# Altium schematic sheet 01_ATmega2560_MCU.SchDoc
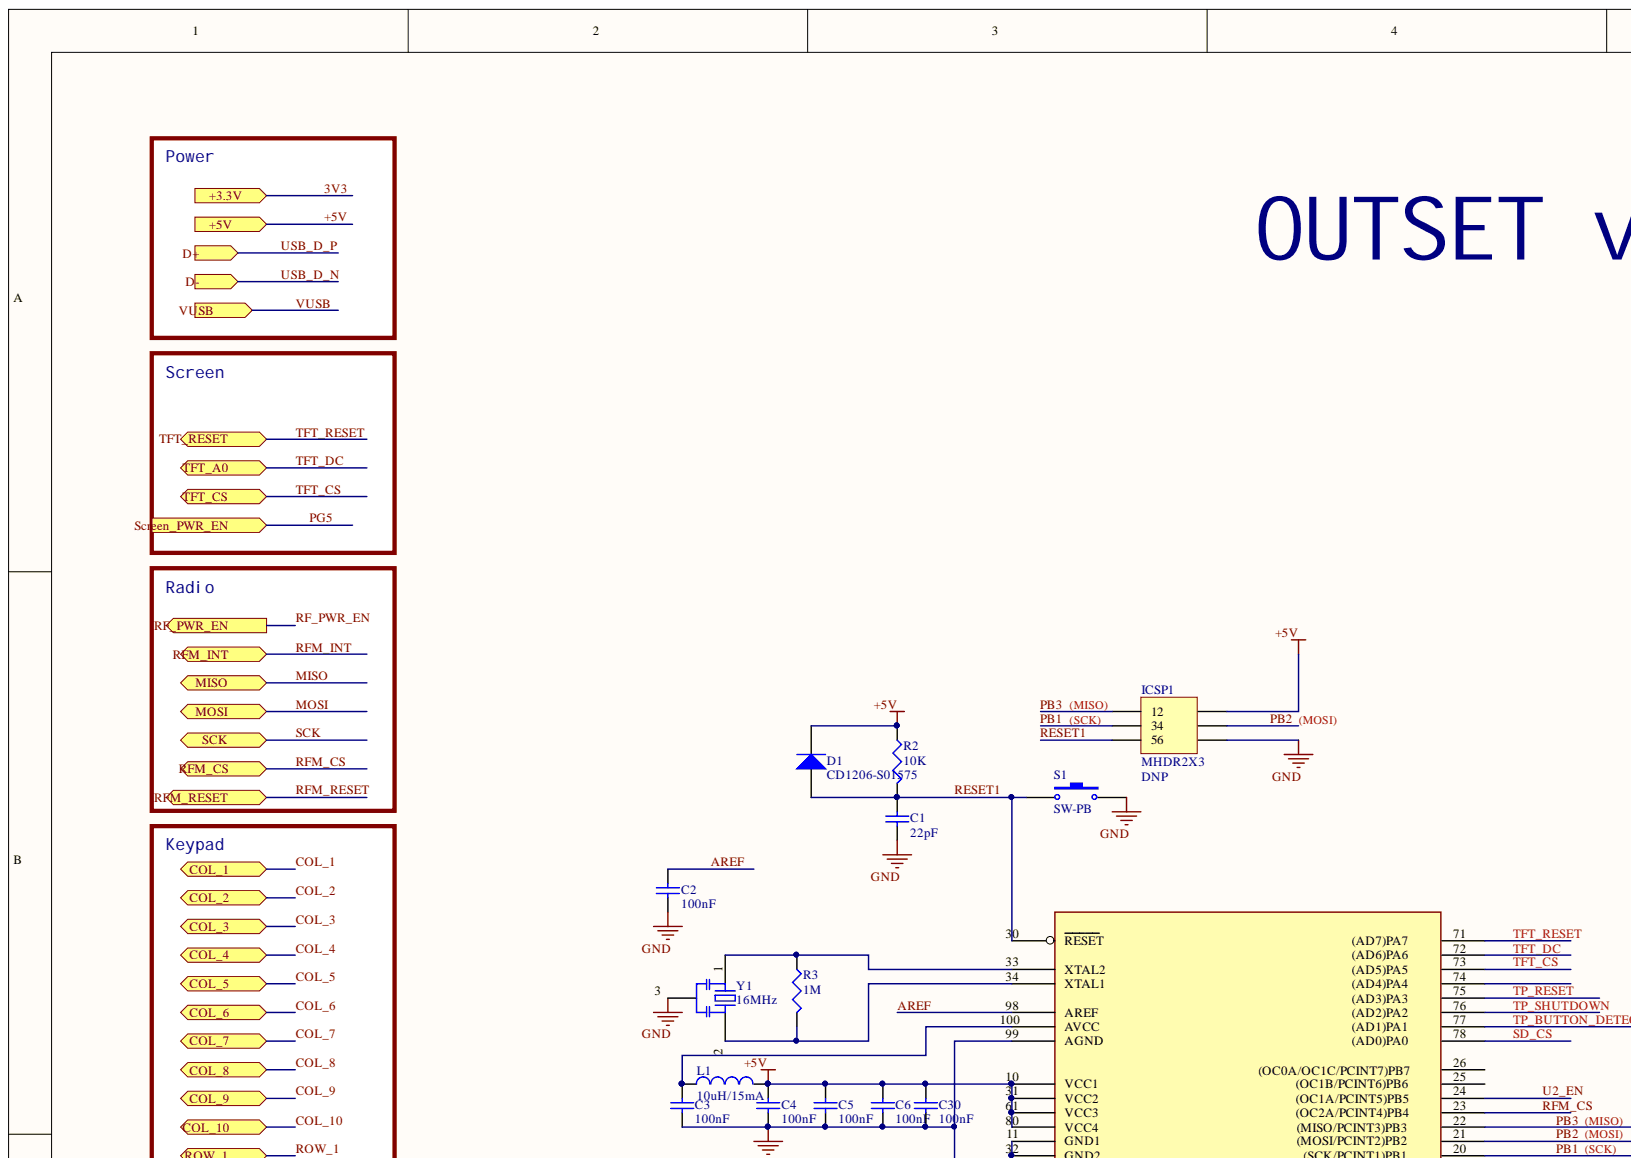
[diagram p0: 01_ATmega2560_MCU.SchDoc, top left]
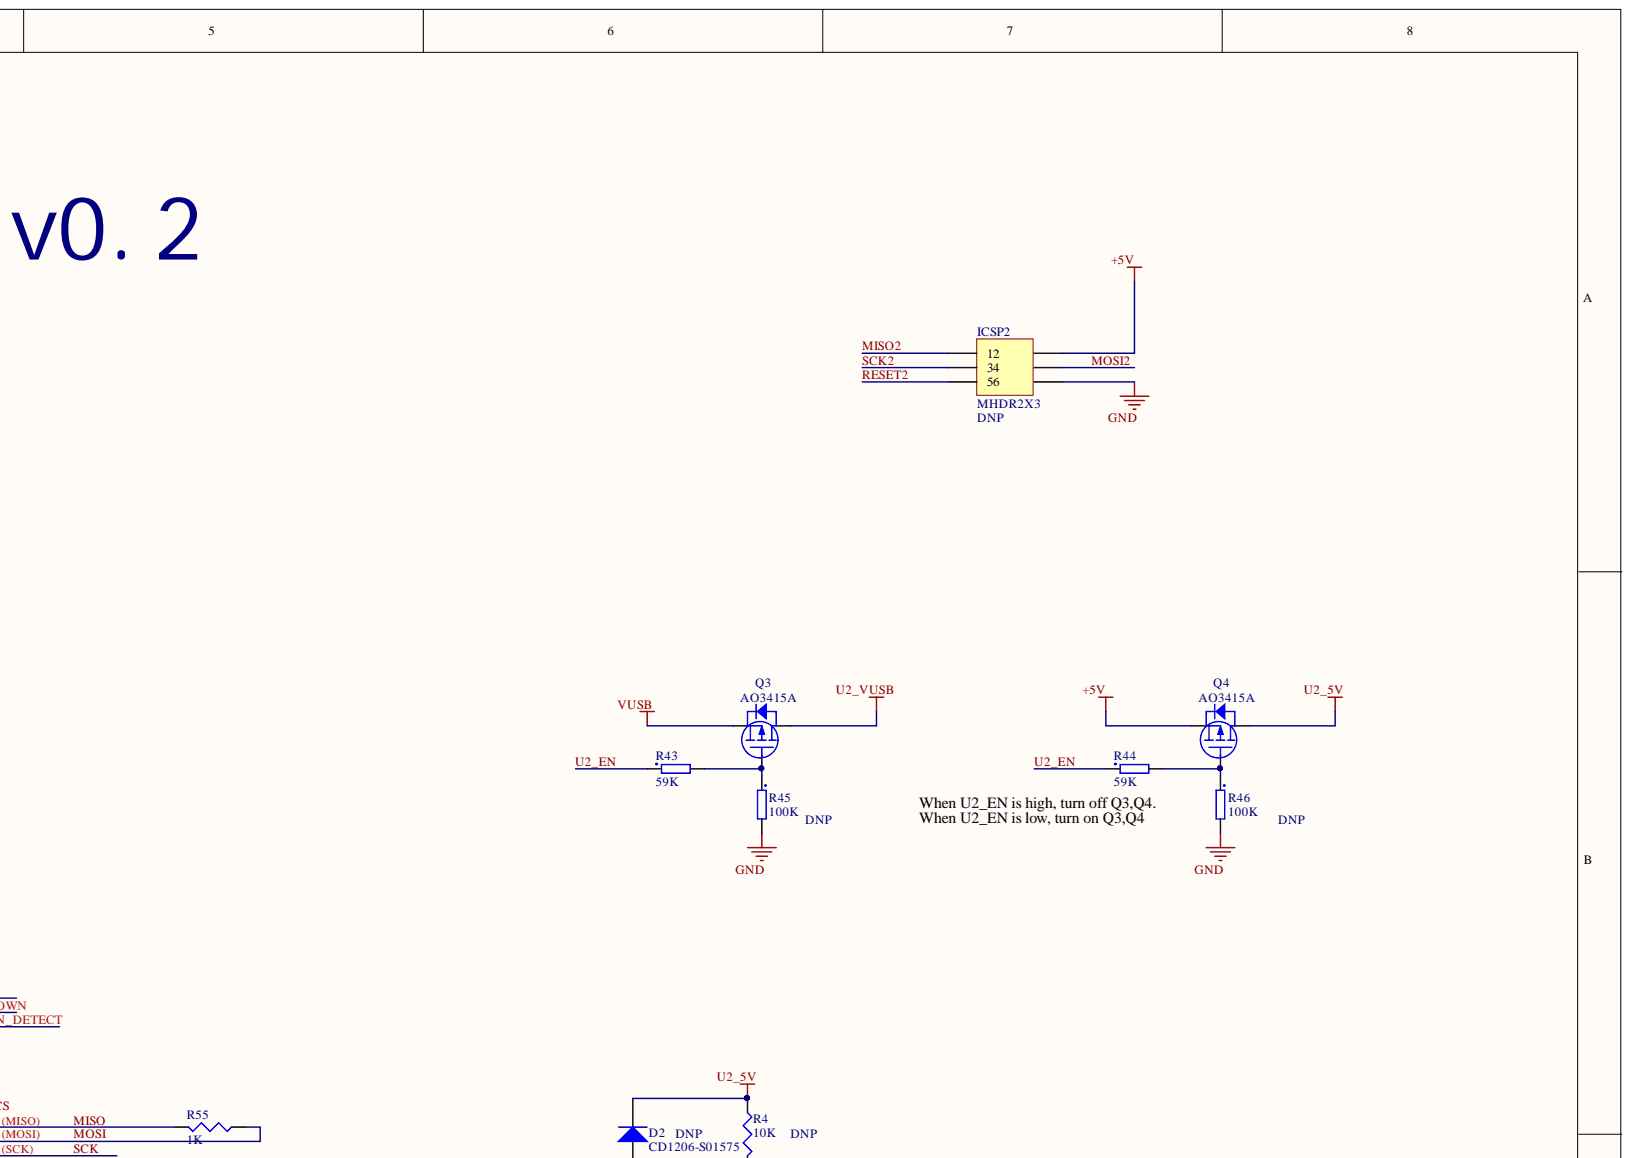
[diagram p1: 01_ATmega2560_MCU.SchDoc, top right]
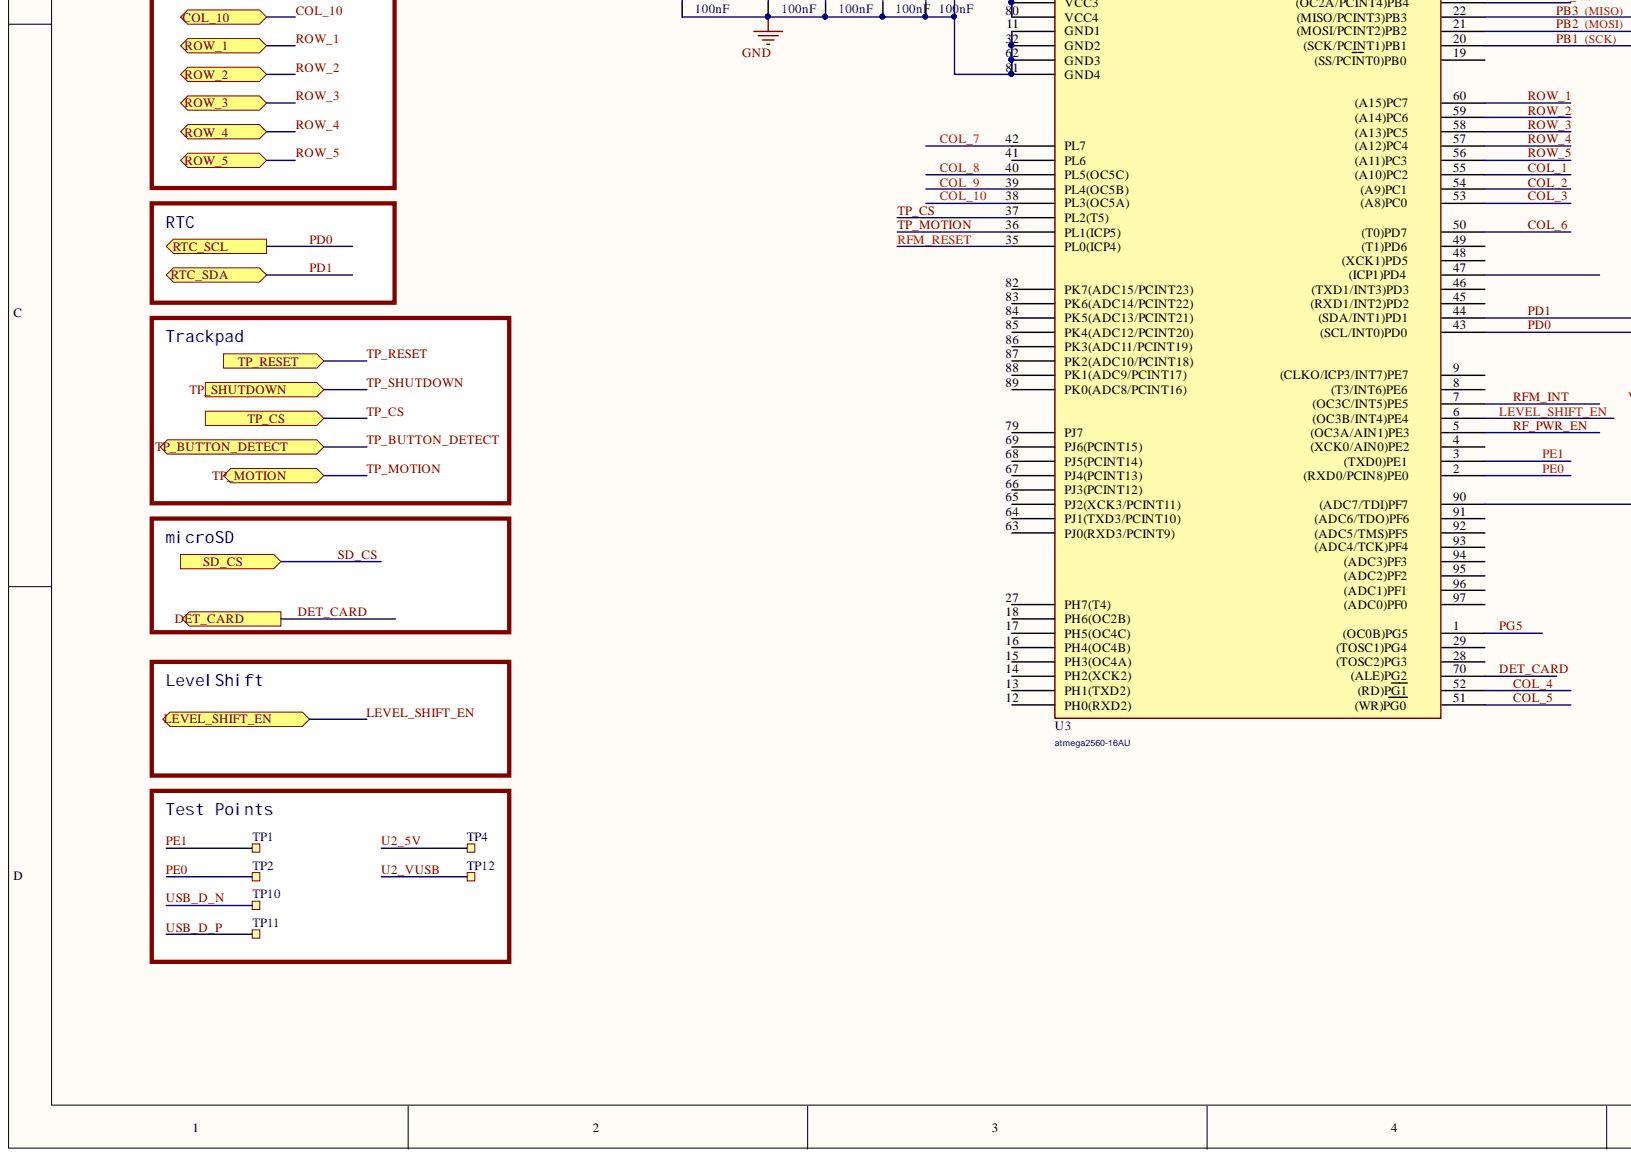
[diagram p2: 01_ATmega2560_MCU.SchDoc, bottom left]
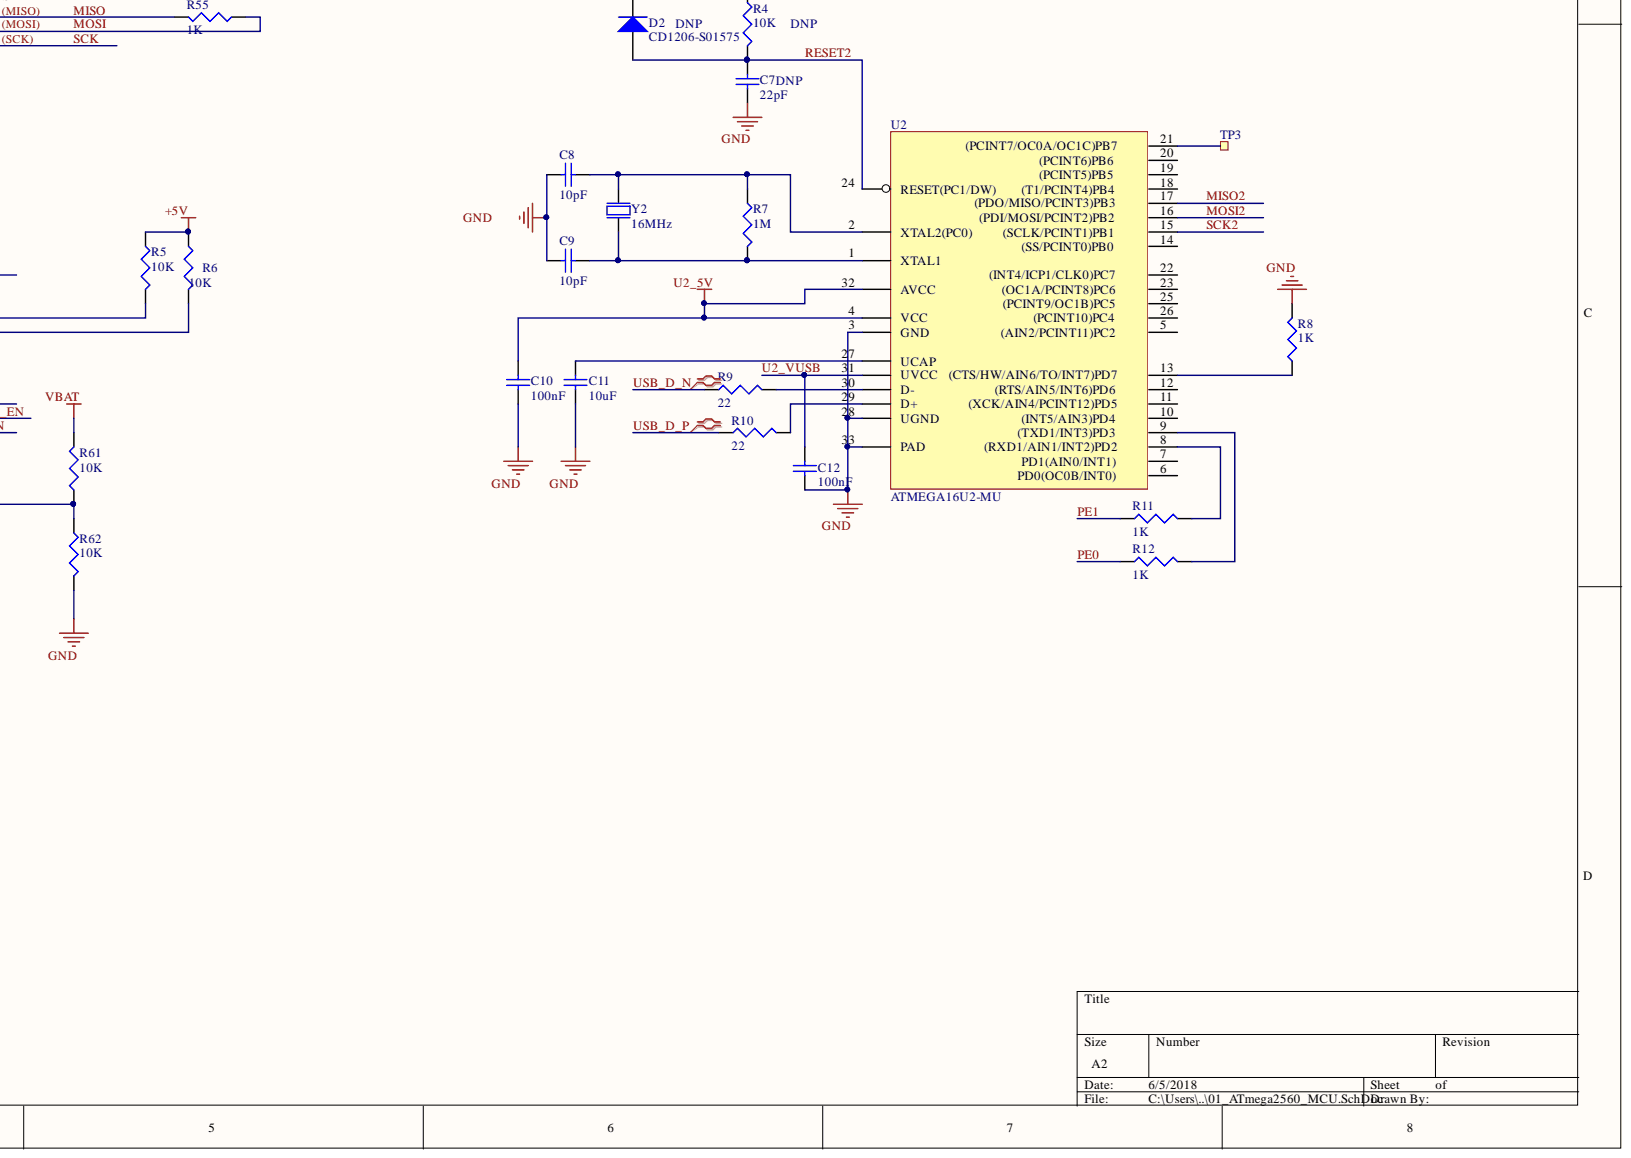
[diagram p3: 01_ATmega2560_MCU.SchDoc, bottom right]

## Parts
C1  22pF  [CAP_0603_SMD]
C2  100nF  [CAP_0603_SMD]
C3  100nF  [CAP_0603_SMD]
C4  100nF  [CAP_0603_SMD]
C5  100nF  [CAP_0603_SMD]
C6  100nF  [CAP_0603_SMD]
C7  22pF  [CAP_0603_SMD]
C8  10pF  [CAP_0603_SMD]
C9  10pF  [CAP_0603_SMD]
C10  100nF  [CAP_0603_SMD]
C11  10uF  [CAP_0603_SMD]
C12  100nF  [CAP_0603_SMD]
C30  100nF  [CAP_0603_SMD]
D1  CD1206-S01575  [DIODE-1206]
D2  CD1206-S01575  [DIODE-1206]
ICSP1  MHDR2X3  [MHDR2X3]
ICSP2  MHDR2X3  [MHDR2X3]
L1  10uH/15mA  [INDC1608X06L]
Q3  AO3415A  [SOT23-D]
Q4  AO3415A  [SOT23-D]
R2  10K  [RES_0603_SMD]
R3  1M  [RES_0603_SMD]
R4  10K  [RES_0603_SMD]
R5  10K  [RES_0603_SMD]
R6  10K  [RES_0603_SMD]
R7  1M  [RES_0603_SMD]
R8  1K  [RES_0603_SMD]
R9  22  [RES_0603_SMD]
R10  22  [RES_0603_SMD]
R11  1K  [RES_0603_SMD]
R12  1K  [RES_0603_SMD]
R43  59K  [RES_0603_smd]
R44  59K  [RES_0603_smd]
R45  100K  [RES_0603_smd]
R46  100K  [RES_0603_smd]
R55  1K  [RES_0603_SMD]
R61  10K  [RES_0603_SMD]
R62  10K  [RES_0603_SMD]
S1  SW-PB  [TACTILE_SWITCH_SMD]
TP1  TP  [TP_1mm]  (no BOM)
TP2  TP  [TP_1mm]  (no BOM)
TP3  TP  [TP_1mm]  (no BOM)
TP4  TP  [TP_1mm]  (no BOM)
TP10  TP  [TP_1mm]  (no BOM)
TP11  TP  [TP_1mm]  (no BOM)
TP12  TP  [TP_1mm]  (no BOM)
U2  ATMEGA16U2-MU  [QFN32]
U3  atmega2560-16AU  [LQFP-100(MS-026)]
Y1  16MHz  [CSTCE_V]
Y2  16MHz  [BT-XTAL_3225]

## Ports
+3.3V, +5V, COL_1, COL_2, COL_3, COL_4, COL_5, COL_6, COL_7, COL_8, COL_9, COL_10, D+, D-, DET_CARD, LEVEL_SHIFT_EN, MISO, MOSI, RF_PWR_EN, RFM_CS, RFM_INT, RFM_RESET, ROW_1, ROW_2, ROW_3, ROW_4, ROW_5, RTC_SCL, RTC_SDA, SCK, SCREEN_PWR_EN, SD_CS, TFT_A0, TFT_CS, TFT_RESET, TP_BUTTON_DETECT, TP_CS, TP_MOTION, TP_RESET, TP_SHUTDOWN, VUSB

## Nets
GND: C1.2 C2.2 C3.2 C4.2 C5.2 C6.2 C7.2 C8.1 C9.1 C10.2 C11.2 C12.2 C30.2 ICSP1.6 ICSP2.6 R8.2 R45.2 R46.2 R62.2 S1.2 U2.3(GND) U2.28(UGND) U2.33(PAD) U3.11(GND1) U3.32(GND2) U3.62(GND3) U3.81(GND4) U3.99(AGND) Y1.3 Y2.2 Y2.4
VBAT: R61.2
+5V: C4.1 C5.1 C6.1 C30.1 D1.2 ICSP1.2 ICSP2.2 L1.2 Q4.3 R2.1 R5.1 R6.1 U3.10(VCC1) U3.31(VCC2) U3.61(VCC3) U3.80(VCC4) ; port +5V
AREF: C2.1 U3.98(AREF)
COL_1: U3.55 ; port COL_1
COL_2: U3.54 ; port COL_2
COL_3: U3.53 ; port COL_3
COL_4: U3.52 ; port COL_4
COL_5: U3.51 ; port COL_5
COL_6: U3.50 ; port COL_6
COL_7: U3.42(PL7) ; port COL_7
COL_8: U3.40(PL5(OC5C)) ; port COL_8
COL_9: U3.39(PL4(OC5B)) ; port COL_9
COL_10: U3.38(PL3(OC5A)) ; port COL_10
DET_CARD: U3.70 ; port DET_CARD
LEVEL_SHIFT_EN: U3.6 ; port LEVEL_SHIFT_EN
MISO: ICSP1.1 R55.2 U3.22 ; port MISO
MISO2: ICSP2.1 U2.17
MOSI: ICSP1.4 R55.1 U3.21 ; port MOSI
MOSI2: ICSP2.4 U2.16
PB1: ICSP1.3 U3.20 ; port SCK
PD0: R6.2 U3.43 ; port RTC_SCL
PD1: R5.2 U3.44 ; port RTC_SDA
PE0: R12.1 TP2.1 U3.2
PE1: R11.1 TP1.1 U3.3
PG5: U3.1 ; port SCREEN_PWR_EN
RESET1: C1.1 D1.1 ICSP1.5 R2.2 S1.1 U3.30(RESET)
RESET2: C7.1 D2.1 ICSP2.5 R4.2 U2.24(RESET(PC1/DW))
RF_PWR_EN: U3.5 ; port RF_PWR_EN
RFM_CS: U3.23 ; port RFM_CS
RFM_INT: U3.7 ; port RFM_INT
RFM_RESET: U3.35(PL0(ICP4)) ; port RFM_RESET
ROW_1: U3.60 ; port ROW_1
ROW_2: U3.59 ; port ROW_2
ROW_3: U3.58 ; port ROW_3
ROW_4: U3.57 ; port ROW_4
ROW_5: U3.56 ; port ROW_5
SCK2: ICSP2.3 U2.15
SD_CS: U3.78 ; port SD_CS
TFT_CS: U3.73 ; port TFT_CS
TFT_DC: U3.72 ; port TFT_A0
TFT_RESET: U3.71 ; port TFT_RESET
TP_BUTTON_DETECT: U3.77 ; port TP_BUTTON_DETECT
TP_CS: U3.37(PL2(T5)) ; port TP_CS
TP_MOTION: U3.36(PL1(ICP5)) ; port TP_MOTION
TP_RESET: U3.75 ; port TP_RESET
TP_SHUTDOWN: U3.76 ; port TP_SHUTDOWN
U2_5V: C10.1 D2.2 Q4.2 R4.1 TP4.1 U2.4(VCC) U2.32(AVCC)
U2_EN: R43.1 R44.1 U3.24
U2_VUSB: C12.1 Q3.2 TP12.1 U2.31(UVCC)
USB_D_N: R9.1 TP10.1
USB_D_P: R10.1 TP11.1
VUSB: Q3.3 ; port VUSB
NetC3_1: C3.1 L1.1 U3.100(AVCC)
NetC8_2: C8.2 R7.1 U2.2(XTAL2(PC0)) Y2.3
NetC9_2: C9.2 R7.2 U2.1(XTAL1) Y2.1
NetC11_1: C11.1 U2.27(UCAP)
NetQ3_1: Q3.1 R43.2 R45.1
NetQ4_1: Q4.1 R44.2 R46.1
NetR3_1: R3.1 U3.33(XTAL2) Y1.1
NetR3_2: R3.2 U3.34(XTAL1) Y1.2
NetR8_1: R8.1 U2.13
NetR9_2: R9.2 U2.30(D-)
NetR10_2: R10.2 U2.29(D+)
NetR11_2: R11.2 U2.8
NetR12_2: R12.2 U2.9
NetR61_1: R61.1 R62.1 U3.90
NetTP3_1: TP3.1 U2.21
3V3: ; port +3.3V

Not connected: U2 5 6 7 10 11 12 14 18 19 20 22 23 25 26; U3 4 8 9 12(PH0(RXD2)) 13(PH1(TXD2)) 14(PH2(XCK2)) 15(PH3(OC4A)) 16(PH4(OC4B)) 17(PH5(OC4C)) 18(PH6(OC2B)) 19 25 26 27(PH7(T4)) 28 29 41(PL6) 45 46 47 48 49 63(PJ0(RXD3/PCINT9)) 64(PJ1(TXD3/PCINT10)) 65(PJ2(XCK3/PCINT11)) 66(PJ3(PCINT12)) 67(PJ4(PCINT13)) 68(PJ5(PCINT14)) 69(PJ6(PCINT15)) 74 79(PJ7) 82(PK7(ADC15/PCINT23)) 83(PK6(ADC14/PCINT22)) 84(PK5(ADC13/PCINT21)) 85(PK4(ADC12/PCINT20)) 86(PK3(ADC11/PCINT19)) 87(PK2(ADC10/PCINT18)) 88(PK1(ADC9/PCINT17)) 89(PK0(ADC8/PCINT16)) 91 92 93 94 95 96 97

## Library data of the parts
C1-C10 C12 C30 = Cap Semi: Capacitor (Semiconductor SIM Model); Component Kind: Standard; LatestRevisionDate: 29-May-2009; LatestRevisionNote: IPC-7351 Footprint Added.; PackageDescription: Chip Capacitor; PackageReference: SMD 0805; Published: 8-Jun-2000; Signal Integrity: Cap Semi; Simulation: CAP; Library Name: C:\Users\Public\Documents\Altium\AD16\Library\Miscellaneous Devices.IntLib; Publisher: Altium Limited
  C1 C7: Value: 22pF
  C2-C6 C10 C12 C30: Value: 100nF
  C8 C9: Value: 10pF
C11 = Cap Semi: Capacitor (Semiconductor SIM Model); Manufacturer Part Number: GRM188R60J106ME84D; Component Kind: Standard; LatestRevisionDate: 29-May-2009; LatestRevisionNote: IPC-7351 Footprint Added.; PackageDescription: Chip Capacitor; PackageReference: SMD 0805; Published: 8-Jun-2000; Signal Integrity: Cap Semi; Simulation: CAP; Value: 10uF; Library Name: C:\Users\Public\Documents\Altium\AD16\Library\Miscellaneous Devices.IntLib; Publisher: Altium Limited
D1 D2 = Diode: Default Diode; Code_JEDEC: DO-214-AB; Component Kind: Standard; LatestRevisionDate: 17-Jul-2002; LatestRevisionNote: Re-released for DXP Platform.; Library Name: Miscellaneous Devices.IntLib; Manufacturer Part Number: CD1206-S01575; PackageDescription: DO-214-AB/SMC; 2 C-Bend Leads; Body 7.9 x 5.9 mm, inc. leads (LxW); PackageReference: SMC; PackageVersion: Sep-1996; Published: 8-Jun-2000; Signal Integrity: Diode; Simulation: DIODE; Publisher: Altium Limited
ICSP1 ICSP2 = MHDR2X3: Header, 3-Pin, Dual row; Component Kind: Standard; LatestRevisionDate: 17-Jul-2002; LatestRevisionNote: Re-released for DXP Platform.; Library Name: Miscellaneous Connectors.IntLib; Signal Integrity: Connector; Publisher: Altium Limited
L1 = Inductor: Manufacturer Part Number: CV20120-100K; Code_IEC: 1005; Code_JEITA: 0402; Component Kind: Standard; Description: Inductor; LatestRevisionDate: 29-May-2009; LatestRevisionNote: IPC-7351 Footprint Added.; Library Name: Miscellaneous Devices.IntLib; PackageDescription: Chip Inductor; PackageReference: 0805; Published: 8-Jun-2000; Signal Integrity: Inductor; Simulation: INDUCTOR; Value: 10uH/15mA; Publisher: Altium Limited
Q3 Q4 = MOS-P-D: Component Kind: Standard; Library Name: M46_SCHLIB.SchLib
R2 = Res3: Resistor; Manufacturer Part Number: CRCW060310K0FKED; Component Kind: Standard; LatestRevisionDate: 29-May-2009; LatestRevisionNote: IPC-7351 Footprint Added.; PackageDescription: Chip Resistor; PackageReference: SMD 0805; Published: 8-Jun-2000; Signal Integrity: Res; Simulation: RESISTOR; Value: 10K; Library Name: C:\Users\Public\Documents\Altium\AD16\Library\Miscellaneous Devices.IntLib; Publisher: Altium Limited
R3-R12 R55 R61 R62 = Res3: Resistor; Component Kind: Standard; LatestRevisionDate: 29-May-2009; LatestRevisionNote: IPC-7351 Footprint Added.; PackageDescription: Chip Resistor; PackageReference: SMD 0805; Published: 8-Jun-2000; Signal Integrity: Res; Simulation: RESISTOR; Library Name: C:\Users\Public\Documents\Altium\AD16\Library\Miscellaneous Devices.IntLib; Publisher: Altium Limited
  R3 R7: Value: 1M
  R4-R6 R61 R62: Value: 10K
  R8 R11 R12 R55: Value: 1K
  R9 R10: Value: 22
R43-R46 = RES: Resistor; Component Kind: Standard; Library Name: M46_SCHLIB.SchLib
S1 = SW-PB: Switch; Component Kind: Standard; LatestRevisionDate: 17-Jul-2002; LatestRevisionNote: Re-released for DXP Platform.; Library Name: Miscellaneous Devices.IntLib; PackageDescription: Switch; 2 Leads; PackageReference: SPST-2; Published: 24-Mar-1999; Publisher: Altium Limited
TP1-TP4 TP10-TP12 = Testpoint TH: Testpoint, through hole; Artikkelnr Noca: N.A.; Artikkelnr Simpro: N.A.; Footprint Doc: TESTPAD-TH; LatestRevisionDate: 2009-12-12; Library Name: pca10056.IntLib; Part Number: Testpoint TH; Pin Count: 1; Published: 2009-12-12; Value: TP; Publisher: Nordic Semiconductor
U2 = ATMEGA8/16/32U2: 8-bit MCU with USB controller; Component Kind: Standard; Library Name: ATmega2560_Library.SchLib; Manufacturer Part Number: ATMEGA16U2-MU
Y1 = CSTCE_Crystal: Crystal with load_cap built-in; Manufacturer Part Number: CSTCE16M0V53-R0; Component Kind: Standard; Library Name: ATmega2560_Library.SchLib; value: 16MHz
Y2 = XTAL_SMD2016_32MHz_8pF_Golledge: XTAL SMD 3225, 16MHz, Cl=10pF, Tol: ±10ppm, Stab: ±15ppm; Manufacturer Part Number: NX3225SA-16MHZ-STD-CSR-3; Part Number: XTAL_SMD2016_16MHz_8pF_Golledge; Artikkelnr Noca: N.A.; Database Table Name: Crystal_Osc$; Note: XTAL SMD 16.000MHz; Artikkelnr Simpro: N.A.; Component Kind: Standard; ComponentLink1Description: Datasheet; ComponentLink2Description: Supplier link; Footprint Doc: XTAL_2016; HelpURL: \\hizz\pro\app9717\Datasheet\crystals\Golledge\mp06003.pdf; LatestRevisionDate: 2016-11-02; Library Name: Nordic Discrete DB.DbLib; Manufacturer: Golledge; Pin Count: 4; Published: 2016-11-02; Supplier: Link Nordic; Value: 16MHz; Publisher: Nordic Semiconductor
U3 = atmega2560-16AU: Component Kind: Standard; Library Name: ATmega2560_Library.SchLib
